# Altium schematic sheet ESP32.SchDoc
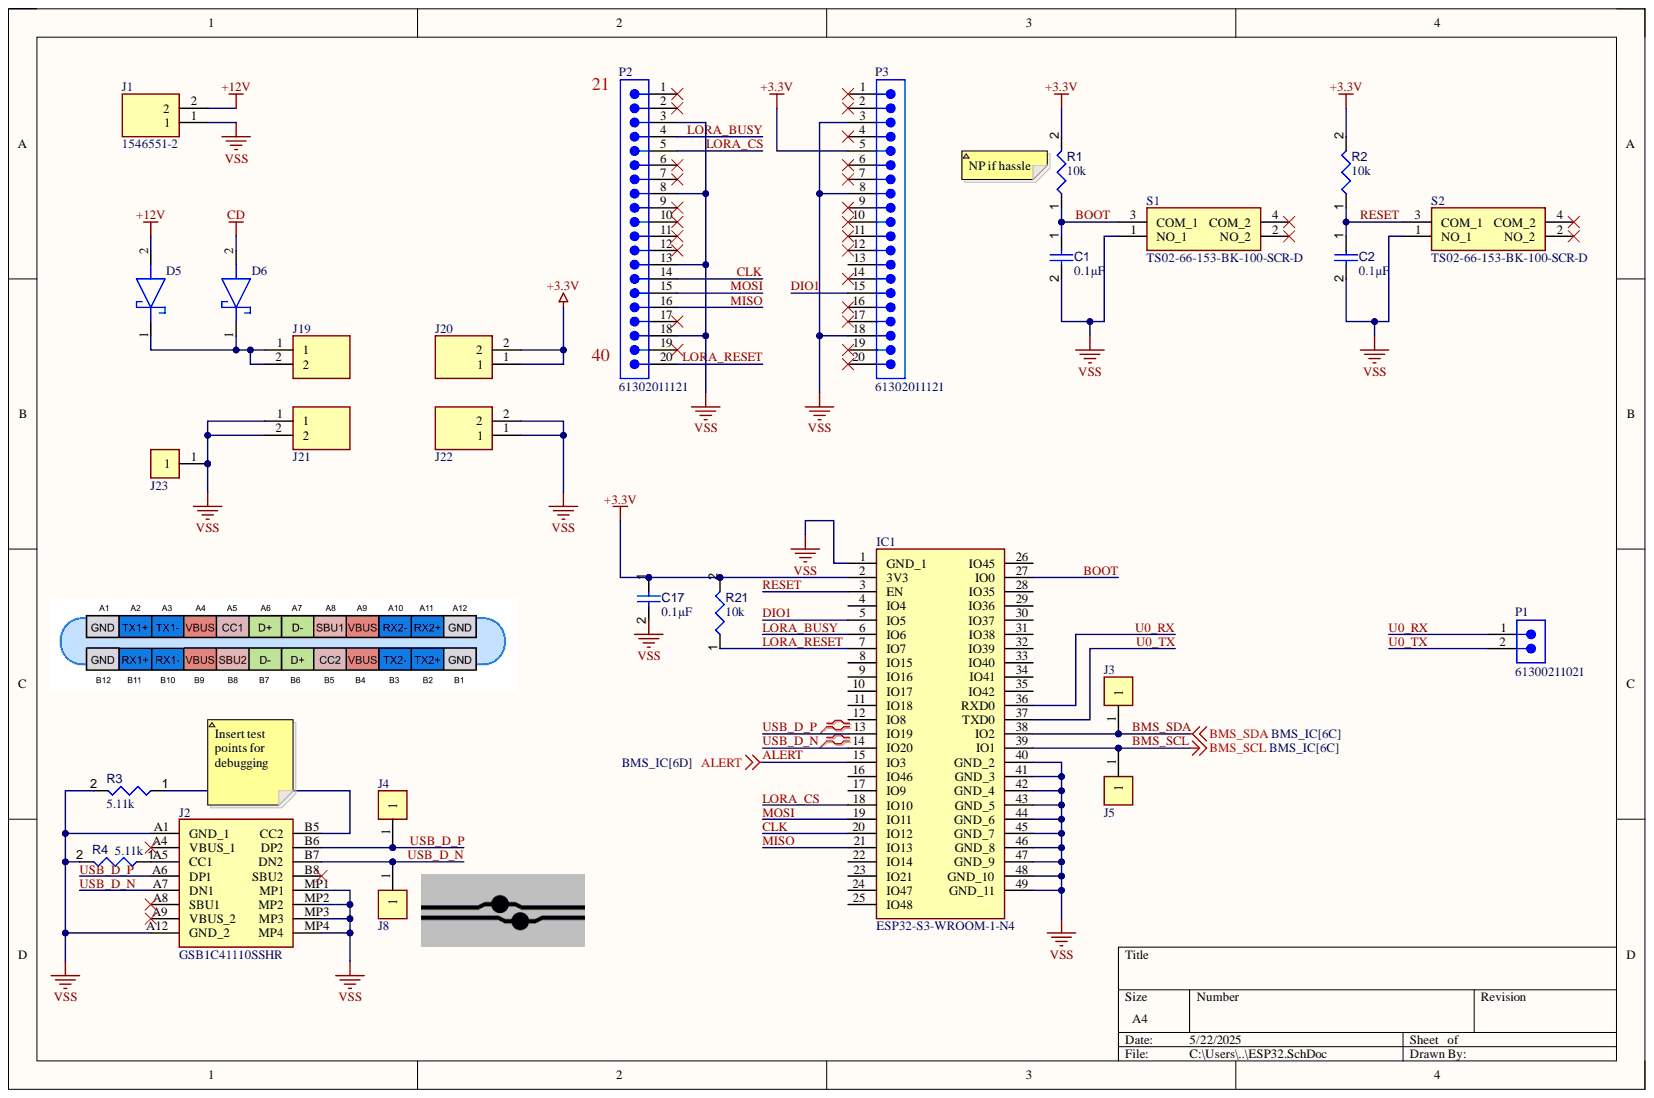
[diagram p0: ESP32.SchDoc]

## Parts
C1  value 0.1µF  [CAPC1608X94X35NL15T15]  (hidden comment: Capacitor 1 uF +/- 10% 16 V 0603)
C2  value 0.1µF  [CAPC1608X94X35NL15T15]  (hidden comment: Capacitor 1 uF +/- 10% 16 V 0603)
C17  value 0.1µF  [CAPC1608X94X35NL15T15]  (hidden comment: Capacitor 1 uF +/- 10% 16 V 0603)
D5  FM5818-W  [DIOM5025X231N]  (value not drawn)
D6  FM5818-W  [DIOM5025X231N]  (value not drawn)
IC1  ESP32-S3-WROOM-1-N4  [ESP32S3WROOM1N4]
J1  1546551-2  [15465512]
J2  GSB1C41110SSHR  [GSB1C41110SSHR]
J3  5001  [FP-5001-MFG]  (value not drawn)
J4  5001  [FP-5001-MFG]  (value not drawn)
J5  5001  [FP-5001-MFG]  (value not drawn)
J8  5001  [FP-5001-MFG]  (value not drawn)
J19  67996-100HLF  [HDRV2W67P254_2X1_254X483X858P]  (value not drawn)
J20  67996-100HLF  [HDRV2W67P254_2X1_254X483X858P]  (value not drawn)
J21  67996-100HLF  [HDRV2W67P254_2X1_254X483X858P]  (value not drawn)
J22  67996-100HLF  [HDRV2W67P254_2X1_254X483X858P]  (value not drawn)
J23  5001  [FP-5001-MFG]  (value not drawn)
P1  61300211021  [61300211021]
P2  61302011121  [61302011121]
P3  61302011121  [61302011121]
R1  value 10k  [RESC1608X55X25ML10T15]  (hidden comment: Resistor 10k +/-1% 0603 100 mW)
R2  value 10k  [RESC1608X55X25ML10T15]  (hidden comment: Resistor 10k +/-1% 0603 100 mW)
R3  value 5.11k  [RESC1608X55X25ML10T15]  (hidden comment: Resistor 10k +/-1% 0603 100 mW)
R4  value 5.11k  [RESC1608X55X25ML10T15]  (hidden comment: Resistor 10k +/-1% 0603 100 mW)
R21  value 10k  [RESC1608X55X25ML10T15]  (hidden comment: Resistor 10k +/-1% 0603 100 mW)
S1  TS02-66-153-BK-100-SCR-D  [TS0266153BK100SCRD]
S2  TS02-66-153-BK-100-SCR-D  [TS0266153BK100SCRD]

## Nets
+3.3V: C17.1 IC1.2(3V3) J20.1 J20.2 P3.5 R1.2 R2.2 R21.2
+12V: D5.2 J1.2
CD: D6.2
VSS: C1.2 C2.2 C17.2 IC1.1(GND_1) IC1.40(GND_2) IC1.41(GND_3) IC1.42(GND_4) IC1.43(GND_5) IC1.44(GND_6) IC1.45(GND_7) IC1.46(GND_8) IC1.47(GND_9) IC1.48(GND_10) IC1.49(GND_11) J1.1 J2.A1(GND_1) J2.A12(GND_2) J2.MP1 J2.MP2 J2.MP3 J2.MP4 J21.1 J21.2 J22.1 J22.2 J23.1 P2.3 P2.8 P2.13 P2.18 P3.3 P3.8 P3.18 R3.2 R4.2 S1.1(NO_1) S2.1(NO_1)
ALERT: IC1.15(IO3)
BMS_SCL: IC1.39(IO1) J5.1
BMS_SDA: IC1.38(IO2) J3.1
BOOT: C1.1 IC1.27(IO0) R1.1 S1.3(COM_1)
CLK: IC1.20(IO12) P2.14
DIO1: IC1.5(IO5) P3.15
LORA_BUSY: IC1.6(IO6) P2.4
LORA_CS: IC1.18(IO10) P2.5
LORA_RESET: IC1.7(IO7) P2.20 R21.1
MISO: IC1.21(IO13) P2.16
MOSI: IC1.19(IO11) P2.15
RESET: C2.1 IC1.3(EN) R2.1 S2.3(COM_1)
U0_RX: IC1.36(RXD0) P1.1
U0_TX: IC1.37(TXD0) P1.2
USB_D_N: IC1.14(IO20) J2.A7(DN1) J2.B7(DN2) J8.1
USB_D_P: IC1.13(IO19) J2.A6(DP1) J2.B6(DP2) J4.1
NetD5_1: D5.1 D6.1 J19.1 J19.2
NetJ2_A5: J2.A5(CC1) R4.1
NetJ2_B5: J2.B5(CC2) R3.1

Not connected: IC1 4(IO4) 8(IO15) 9(IO16) 10(IO17) 11(IO18) 12(IO8) 16(IO46) 17(IO9) 22(IO14) 23(IO21) 24(IO47) 25(IO48) 26(IO45) 28(IO35) 29(IO36) 30(IO37) 31(IO38) 32(IO39) 33(IO40) 34(IO41) 35(IO42); J2 A4(VBUS_1) A8(SBU1) A9(VBUS_2) B8(SBU2); P2 1 2 6 7 9 10 11 12 17 19; P3 1 2 4 6 7 9 10 11 12 13 14 16 17 19 20; S1 2(NO_2) 4(COM_2); S2 2(NO_2) 4(COM_2)

## Library data of the parts
C1 C2 C17 = CMP-001-00003-6: Chip Capacitor, 1 uF, +/- 10%, 16 V, 0603 (1608 Metric); Capacitor Type: Ceramic; Voltage Rating: 16V; Case/Package: 0603; Component Kind: Standard; Max Operating Temperature: 125°C; Min Operating Temperature: -55°C; Mounting Technology: SM; Pins: 2; RoHS Compliant: True; Tolerance: 10%; Library Name: University of New South Wales
D5 D6 = FM5818-W: Schottky Diode; Manufacturer_Name: Rectron; Component Kind: Standard; Datasheet Link: https://www.mouser.com/datasheet/2/345/fm5817-5819-29163.pdf; Height: 2.31mm; Manufacturer_Part_Number: FM5818-W; Mouser Part Number: 583-FM5818; Mouser Price/Stock: https://www.mouser.co.uk/ProductDetail/Rectron/FM5818-W?qs=Xh%252B%2F7fPmomd%2FBysMrWnTwQ%3D%3D
IC1 = ESP32-S3-WROOM-1-N4: Integrated Circuit; Manufacturer_Name: Espressif Systems; Component Kind: Standard; Datasheet Link: https://www.espressif.com/sites/default/files/documentation/esp32-s3-wroom-1_wroom-1u_datasheet_en.pdf; Height: 3.25mm; Manufacturer_Part_Number: ESP32-S3-WROOM-1-N4; Mouser Part Number: 356-ESP32-S3WROOM1N4; Mouser Price/Stock: https://www.mouser.co.uk/ProductDetail/Espressif-Systems/ESP32-S3-WROOM-1-N4?qs=Li%252BoUPsLEnsO%2FkiJOUK0FQ%3D%3D
J1 = 1546551-2: Connector; Manufacturer_Name: TE Connectivity; Arrow Part Number: 1546551-2; Arrow Price/Stock: https://www.arrow.com/en/products/1546551-2/te-connectivity?region=nac; Component Kind: Standard; Datasheet Link: https://www.te.com/commerce/DocumentDelivery/DDEController?Action=srchrtrv&DocNm=1-1773458-1_EURO_STYLE_QRG&DocType=Data%20Sheet&DocLang=English&PartCntxt=1546551-2&DocFormat=pdf; Height: 8.5mm; Manufacturer_Part_Number: 1546551-2; Mouser Part Number: 571-1546551-2; Mouser Price/Stock: https://www.mouser.co.uk/ProductDetail/TE-Connectivity/1546551-2?qs=m%2FhmcwQ75LmjcSTRMY1QVQ%3D%3D
J2 = GSB1C41110SSHR: Connector; Manufacturer_Name: Amphenol Communications Solutions; Component Kind: Standard; Datasheet Link: https://cdn.amphenol-cs.com/media/wysiwyg/files/drawing/gsb1c41x10sshr-ax1.pdf; Height: 3.41mm; Manufacturer_Part_Number: GSB1C41110SSHR
J3-J5 J8 J23 = CMP-2000-05181-2: PC TEST POINT MINIATURE BLACK; Body Material: Bronze; Hole Diameter: 1.6mm; Inside Diameter: 1.27mm; Insulation: Insulated; Number of Contacts: 1; Outside Diameter: 2.54mm; Plating: Silver; Color: Black; Component Kind: Standard; Contact Material: Bronze; Contact Plating: Silver; Diameter: 2.54mm; Flammability Rating: UL94 V-0; Height: 0.3inch; Housing Color: Black; Insulation Material: Nylon; Lead Free: Lead Free; Length: 7.62mm; Library Name: Altium Content Vault; Material: Nylon; Mount: Through Hole; Number of Ports: 1; Orientation: Straight; Package Quantity: 100; Packaging: Bulk; REACH SVHC: No SVHC; RoHS Compliant: Yes; Series: THM; Termination: Through Hole
J19-J22 = 67996-100HLF: Connector; Manufacturer_Name: Amphenol Communications Solutions; Component Kind: Standard; Datasheet Link: https://cdn.amphenol-cs.com/media/wysiwyg/files/drawing/67996.pdf; Height: 8.58mm; Manufacturer_Part_Number: 67996-100HLF
P1 = CMP-1502-01059-3: NexarPartId: 32855519; Connector Type: Male Pin; Contact Resistance: 20mΩ; Current Rating: 3A; Gender: Male; Number of Contacts: 2; Operating Supply Voltage: 250V; Pitch: 2.54mm; Color: Black; Component Kind: Standard; Contact Material: Copper; Contact Mating Length: 5.9944mm; Contact Plating: Gold; Lead Pitch: 2.54mm; Library Name: Altium Content Vault; Mount: Through Hole; Number of Pins: 2; Number of Positions: 2; Number of Rows: 1; Packaging: Bulk; REACH SVHC: Unknown; RoHS: Compliant; Termination: Solder
P2 P3 = CMP-1502-01102-3: NexarPartId: 32855554; Connector Type: Male Pin; Contact Resistance: 20mΩ; Current Rating: 3A; Gender: Male; Number of Contacts: 20; Operating Supply Voltage: 250V; Pitch: 2.54mm; Color: Black; Component Kind: Standard; Contact Material: Copper; Contact Mating Length: 5.9944mm; Contact Plating: Gold; Lead Pitch: 2.54mm; Library Name: Altium Content Vault; Mount: Through Hole; Number of Pins: 20; Number of Positions: 20; Number of Rows: 1; Orientation: Vertical; Packaging: Bulk; REACH SVHC: Unknown; RoHS: Compliant; Termination: Solder
R1-R4 R21 = CMP-009-00017-5: Chip Resistor, 10 KOhm, +/- 1%, 01 W, -55 to 155 degC, 0603 (1608 Metric); Power: 100mW; Voltage Rating: 50V; Case/Package: 0603; Component Kind: Standard; Max Operating Temperature: 155°C; Min Operating Temperature: -55°C; Mounting Technology: SM; Pins: 2; RoHS Compliant: True; Tolerance: 1%; Library Name: University of New South Wales
S1 S2 = TS02-66-153-BK-100-SCR-D: Switch; Manufacturer_Name: CUI Devices; Arrow Part Number: TS02-66-153-BK-100-SCR-D; Arrow Price/Stock: https://www.arrow.com/en/products/ts02-66-153-bk-100-scr-d/cui-devices?region=nac; Component Kind: Standard; Datasheet Link: https://www.cuidevices.com/product/resource/pdf/ts02.pdf; Height: 15.5mm; Manufacturer_Part_Number: TS02-66-153-BK-100-SCR-D; Mouser Part Number: 179-TS0266153BK100SC; Mouser Price/Stock: https://www.mouser.co.uk/ProductDetail/CUI-Devices/TS02-66-153-BK-100-SCR-D?qs=A6eO%252BMLsxmRGT0BAsX%252B%252Bww%3D%3D
